# Altium schematic sheet 102-002-00128-00,K12风扇模块V1.0原理图.SchDoc
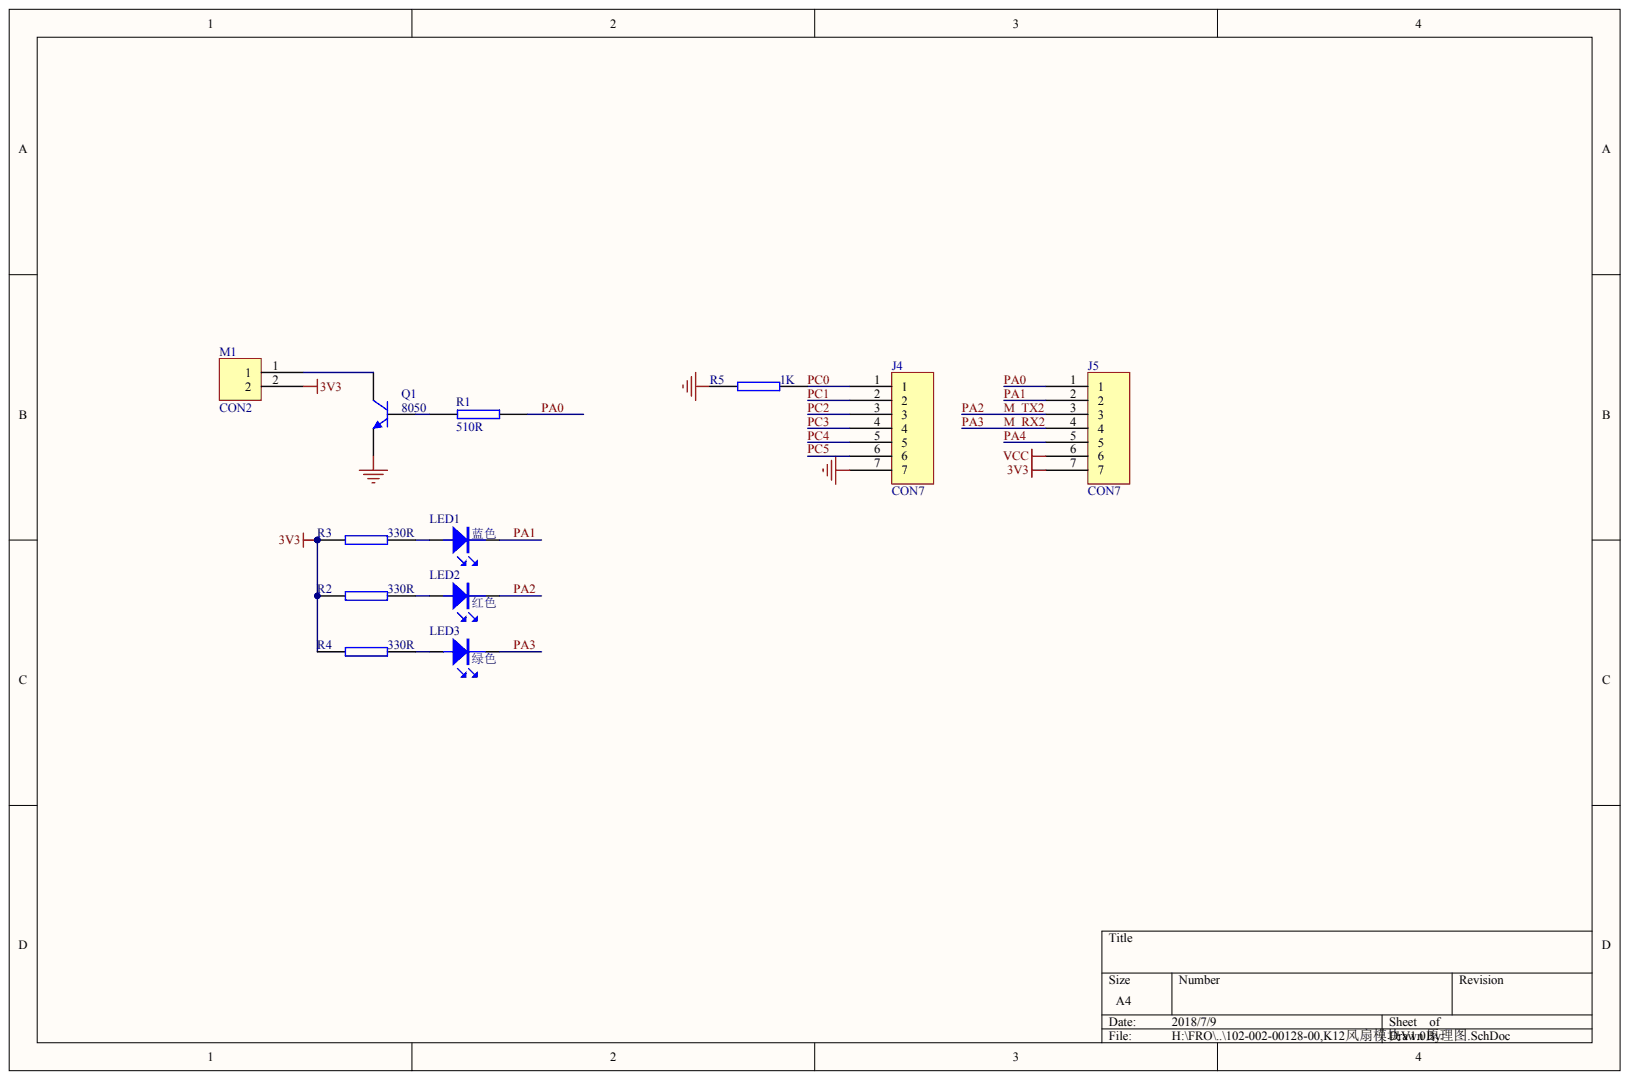
[diagram p0: 102-002-00128-00,K12风扇模块V1.0原理图.SchDoc]

## Parts
J4  CON7
J5  CON7
LED1  BLUE  (value not drawn)
LED2  RED  (value not drawn)
LED3  green  (value not drawn)
M1  CON2
Q1  8050
R1  510R
R2  330R
R3  330R
R4  330R
R5  1K

## Nets
3V3: J5.7 M1.2 R2.1 R3.1 R4.1
GND: J4.7 Q1.2 R5.1
VCC: J5.6
M_RX2: J5.4 LED3.K
M_TX2: J5.3 LED2.K
PA0: J5.1 R1.1
PA1: J5.2 LED1.K
PA4: J5.5
PC0: J4.1 R5.2
PC1: J4.2
PC2: J4.3
PC3: J4.4
PC4: J4.5
PC5: J4.6
NetLED1_A: LED1.A R3.2
NetLED2_A: LED2.A R2.2
NetLED3_A: LED3.A R4.2
NetM1_1: M1.1 Q1.3
NetQ1_1: Q1.1 R1.2

## Library data of the parts
LED1 = (no symbol name): wuliaobianma: 106-002-00005-00; wuliaoguige: 0805/blue; wuliaomingchen: 贴片高亮发光二极管
LED2 = (no symbol name): wuliaobianma: 106-002-00001-00; wuliaoguige: 0805/red; wuliaomingchen: 贴片高亮发光二极管
LED3 = (no symbol name): wuliaobianma: 106-002-00002-00; wuliaoguige: 0805/green; wuliaomingchen: 贴片高亮发光二极管
Q1 = (no symbol name): wuliaobianma: 107-001-00001-00; wuliaoguige: S8050/SOT-23; wuliaomingchen: 贴片三极管
R1 = (no symbol name): wuliaobianma: 103-001-00511-01; wuliaoguige: 0805/510Ω/F; wuliaomingchen: 贴片电阻
R2-R4 = (no symbol name): wuliaobianma: 103-001-00331-01; wuliaoguige: 0805/330Ω/F; wuliaomingchen: 贴片电阻
R5 = (no symbol name): wuliaobianma: 103-001-00102-01; wuliaoguige: 0805/1KΩ/F; wuliaomingchen: 贴片电阻
